# Altium schematic sheet MainBoard_Power.SchDoc
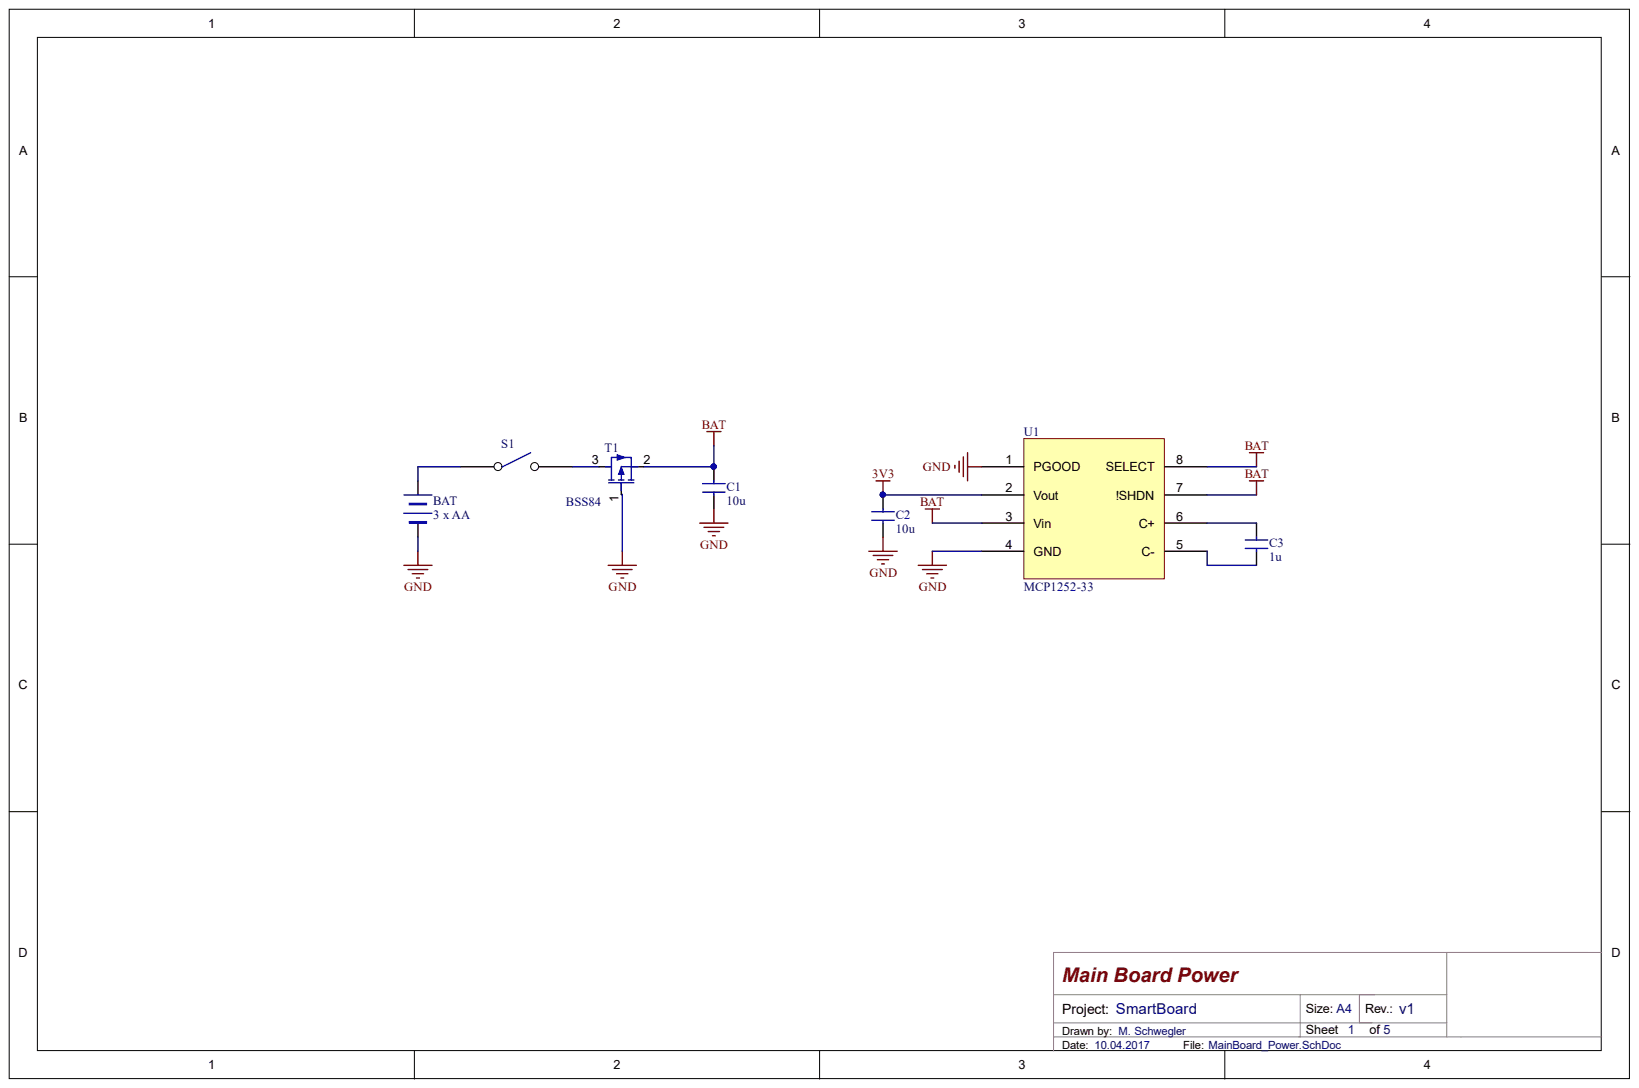
[diagram p0: MainBoard_Power.SchDoc]

## Parts
BAT  3 x AA  [POWER2]
C1  10u  [0805_C]
C2  10u  [0805_C]
C3  1u  [0805_C]
S1  SW SPST  [SIP2]  (value not drawn)
T1  BSS84  [SOT23-3]
U1  MCP1252-33  [MSOP8]

## Nets
3V3: C2.2 U1.2(Vout)
BAT: C1.2 T1.2 U1.3(Vin) U1.7(!SHDN) U1.8(SELECT)
GND: BAT.2 C1.1 C2.1 T1.1 U1.1(PGOOD) U1.4(GND)
NetBAT_1: BAT.1 S1.1
NetC3_1: C3.1 U1.5(C-)
NetC3_2: C3.2 U1.6(C+)
NetS1_2: S1.2 T1.3

## Library data of the parts
BAT = BATTERY: Component Kind: Standard; Library Name: DEVICE_HSLU.SchLib
C1-C3 = C?: Normaler Kondensator; Component Kind: Standard; Library Name: MainBoard.SCHLIB
T1 = MOSFET-P 1G,2D,3S (Diode): Component Kind: Standard; Description: MOSFET-P 1G,2D,3S (Diode); Library Name: Sch_Lib.SchLib
U1 = VOLTREG: Component Kind: Standard; Library Name: MainBoard.SCHLIB
S1 = SW SPST: Component Kind: Standard; Library Name: DEVICE_HSLU.SchLib
